# Altium schematic sheet BandPass Filter.SchDoc("BandPass Filter")
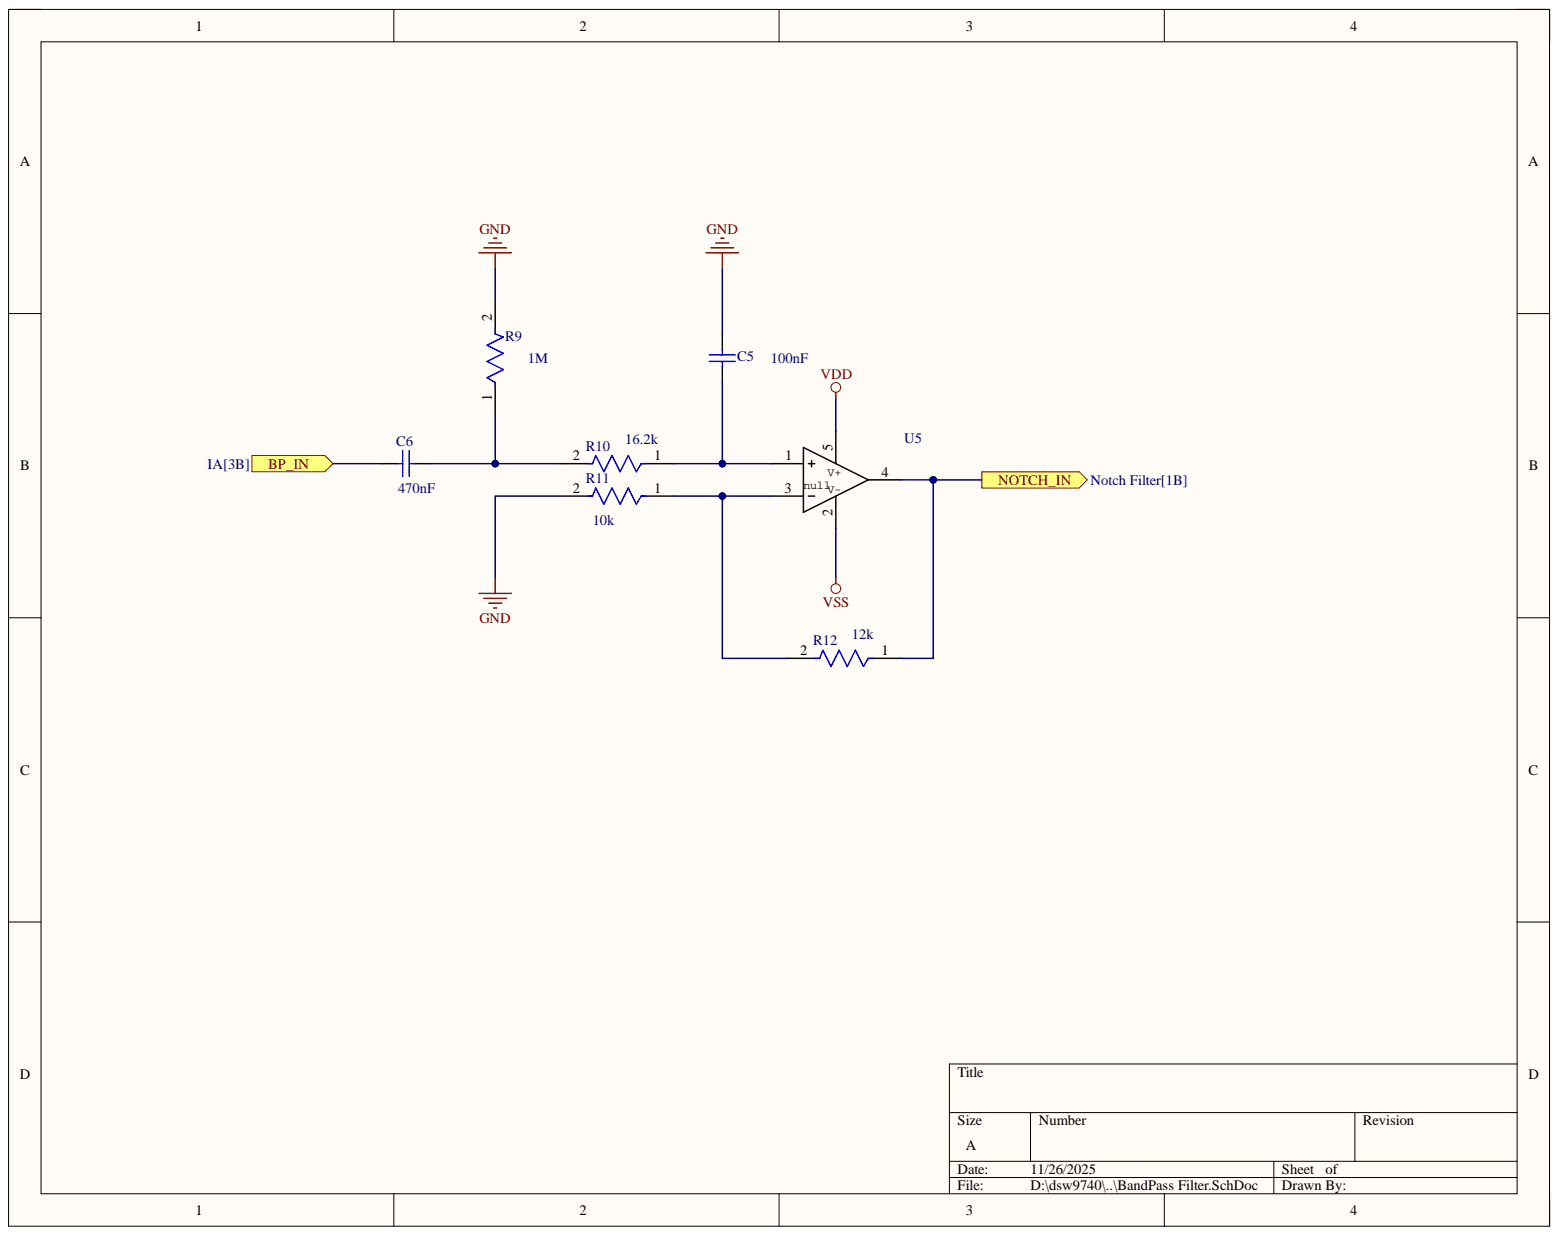
[diagram p0: BandPass Filter.SchDoc]

## Parts
C5  C0805C225K9PACTU  [CAPC2013X145X50ML20T25]  (value not drawn)
C6  C0805C225K9PACTU  [CAPC2013X145X50ML20T25]  (value not drawn)
R9  CRCW080516R0FKEA  [FP-CRCW0805-e3-MFG]  (value not drawn)
R10  CRCW080516R0FKEA  [FP-CRCW0805-e3-MFG]  (value not drawn)
R11  CRCW080516R0FKEA  [FP-CRCW0805-e3-MFG]  (value not drawn)
R12  CRCW080516R0FKEA  [FP-CRCW0805-e3-MFG]  (value not drawn)
U5  TLV9041IDCKR  [DCK0005A-IPC_A]  (value not drawn)

## Ports
BP_IN, NOTCH_IN

## Nets
GND: C5.2 R9.2 R11.2
VDD: U5.5(V+)
VSS: U5.2(V-)
BP_IN: C6.1 ; port BP_IN
NOTCH_IN: R12.1 U5.4 ; port NOTCH_IN
NetC5_1: C5.1 R10.1 U5.1
NetC6_2: C6.2 R9.1 R10.2
NetR11_1: R11.1 R12.2 U5.3

## Library data of the parts
C5 C6 = CMP-1036-04819-2: Manufacturer: Kemet; Component Kind: Standard; Library Name: Altium Content Vault; Part Number: C0805C225K9PACTU
R9-R12 = CMP-02407-002795-1: RES Thick Film, 16Ω, 1%, 0.125W, 100ppm/°C, 0805; Max Power Dissipation: 125mW; Resistance: 16R; Voltage Rating: 150V; Case Code (Imperial): 0805; Case Code (Metric): 2012; Case/Package: 0805; Component Kind: Standard; Composition: Thick Film; Contact Plating: Tin; Depth: 1.25mm; Features: Automotive AEC-Q200; Height: 0.02inch; Lead Free: Lead Free; Length: 2mm; Library Name: Altium Content Vault; Max Operating Temperature: 155°C; Military Standard: Not; Min Operating Temperature: -55°C; Number of Pins: 2; Number of Terminations: 2; Package Quantity: 10; Packaging: Tape and Reel; Power Rating: 125mW; Radiation Hardening: No; Temperature Coefficient: 100ppm/°C; Termination: SMD/SMT; Tolerance: 1%; Voltage Rating (DC): 150V; Weight: 0.000194oz; Width: 0.049inch
U5 = TLV9041IDCKR: Single, 5.5-V, 350-kHz, ultra-low 1.2-V, low-quiescent current (10-Î¼A) op amp 5-SC70 -40 to 125; Manufacturer_Name: Texas Instruments; Component Kind: Standard; Library Name: TLV9041IDCKR.SchLib; Manufacturer_Part_Number: TLV9041IDCKR; RefDes: RefDes; TYPE: TYPE; Copyright: Copyright (C) 2025 Ultra Librarian. All rights reserved.
